# Altium schematic sheet LTE.SchDoc("LTE module")
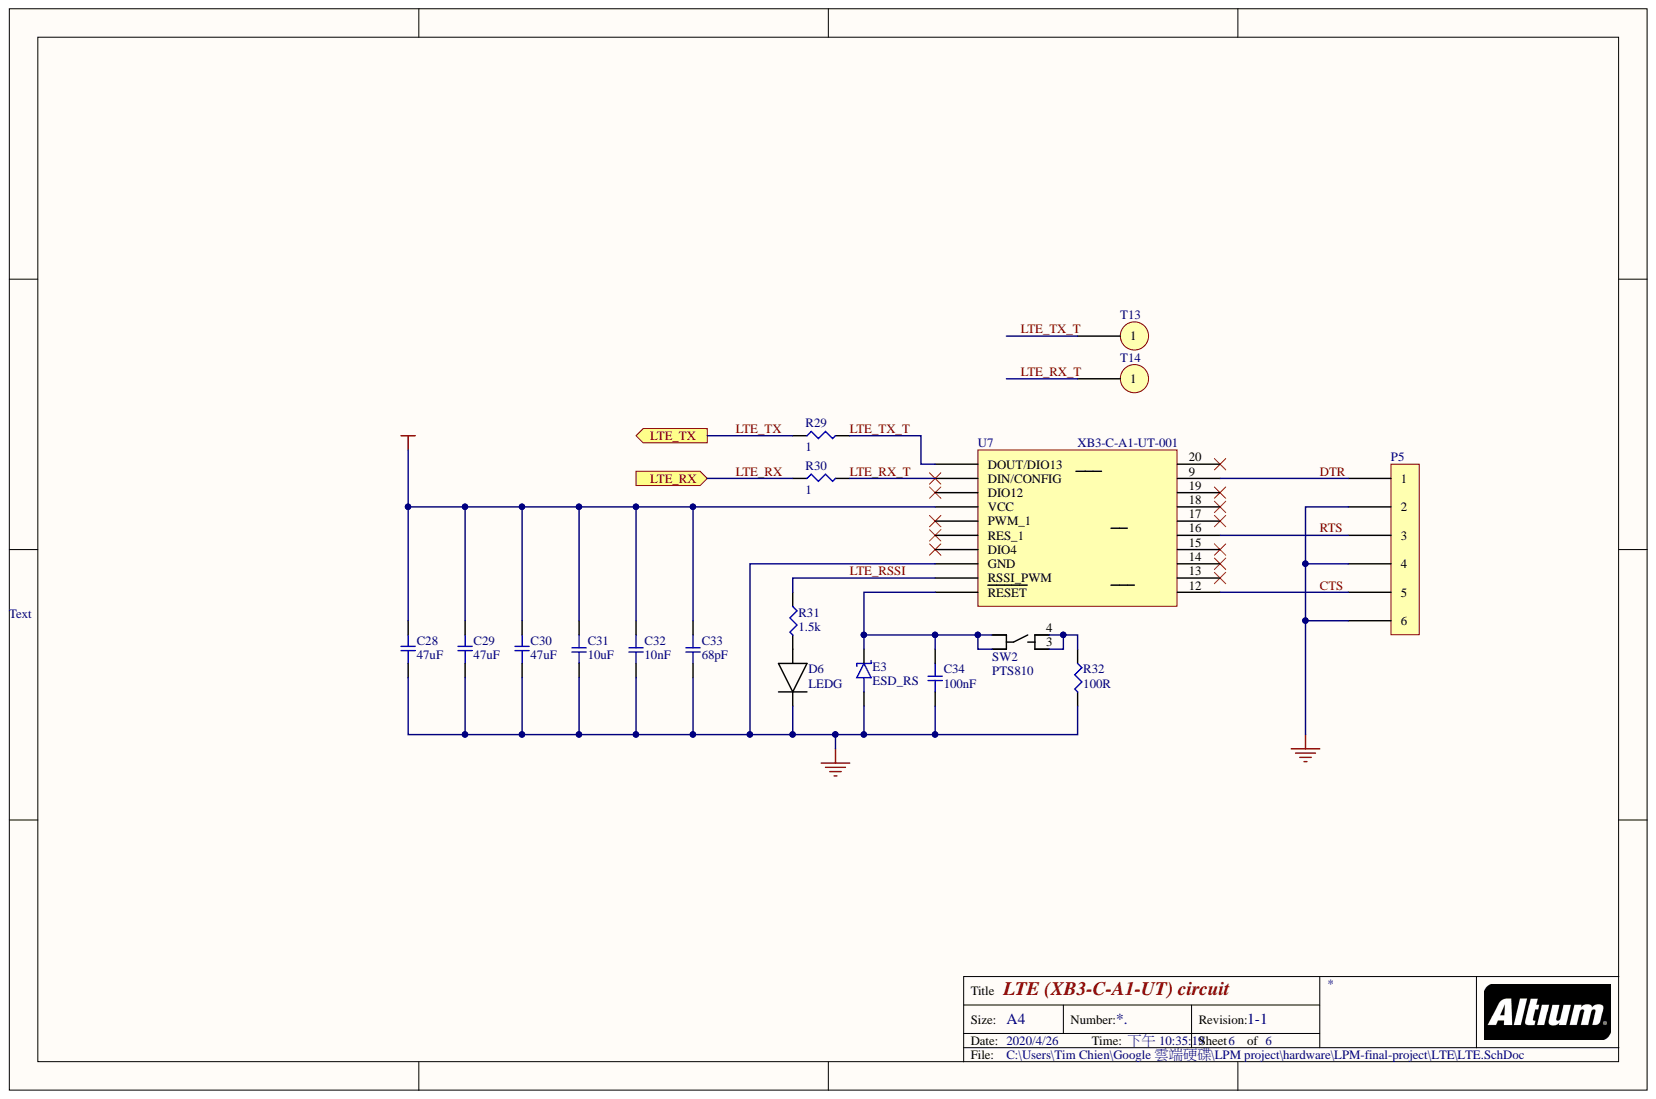
[diagram p0: LTE.SchDoc]

## Parts
C28  47uF  [0805-CAP]
C29  47uF  [0805-CAP]
C30  47uF  [0805-CAP]
C31  value 10uF  [0603-CAP]  (hidden comment: 0603C 10UF)
C32  value 10nF  [0603-CAP]  (hidden comment: 0603C 10NF)
C33  value 68pF  [0603-CAP]  (hidden comment: 0603C 68PF)
C34  value 100nF  [0603-CAP]  (hidden comment: 0603C 100NF)
D6  LEDG  [0603-LED]
E3  ESD_RS  [ESD_RS]
P5  6pins_connector  [6_PINS_2.54]  (value not drawn)
R29  value 1  [0603-RES]  (hidden comment: 0603R 1R)
R30  value 1  [0603-RES]  (hidden comment: 0603R 1R)
R31  value 1.5k  [0603-RES]  (hidden comment: 0603R 1K5R)
R32  value 100R  [0603-RES]  (hidden comment: 0603R 100R)
SW2  PTS810  [*SW_PTS810_SJM_250_SMTR_LFS]
T13  (no value)  [1_PIN_test]
T14  (no value)  [1_PIN_test]
U7  XB3-C-A1-UT-001  [XB24CAPIT-001]

## Ports
LTE_RX, LTE_TX

## Nets
3.3V: C28.2 C29.2 C30.2 C31.1 C32.1 C33.1 U7.1(VCC)
GND: C28.1 C29.1 C30.1 C31.2 C32.2 C33.2 C34.2 D6.2 E3.2 P5.2 P5.4 P5.6 R32.2 U7.10(GND)
CTS: P5.5 U7.12
DTR: P5.1 U7.9
LTE_RSSI: R31.1 U7.6(RSSI_PWM)
LTE_RX: R30.1 ; port LTE_RX
LTE_RX_T: R30.2 T14.1 U7.3(DIN/CONFIG)
LTE_TX: R29.1 ; port LTE_TX
LTE_TX_T: R29.2 T13.1 U7.2(DOUT/DIO13)
RTS: P5.3 U7.16
NetC34_1: C34.1 E3.1 SW2.1 SW2.2 U7.5(RESET)
NetD6_1: D6.1 R31.2
NetR32_1: R32.1 SW2.3 SW2.4

Not connected: U7 4(DIO12) 7(PWM_1) 8(RES_1) 11(DIO4) 13 14 15 17 18 19 20

## Library data of the parts
C28-C30 = 0805C 47UF: Value: 47uF; Supplier Part Number 1: 490-9949-1-ND; Supplier 1: Digi-Key; Library Name: passive components.SchLib; Component Kind: Standard
D6 = 0603 LEDG: Value: LEDG; Supplier Part Number 1: 160-1183-6-ND; Supplier 1: Digi-Key; Library Name: passive components.SchLib; Component Kind: Standard
E3 = ESD_RESET: Supplier Part Number 1: F7701CT-ND; Supplier 1: Digi-Key; Library Name: passive components.SchLib; Component Kind: Standard
SW2 = PTS810_SJM_250_SMTR_LFS: Tactile Switch SPST-NO Top Actuated Surface Mount; MP: PTS810 SJM 250 SMTR LFS; MF: C&K; SnapEDA_Link: https://www.snapeda.com/parts/PTS810%20SJM%20250%20SMTR%20LFS/C%26K/view-part/522770/?ref=snap; Price: 0.3; Package: None; Library Name: PTS810_SJM_250_SMTR_LFS.IntLib; Digikey part number: CKN10502CT-ND; Digikey link: https://www.digikey.com/product-detail/en/c-k/PTS810-SJM-250-SMTR-LFS/CKN10502CT-ND/4176673; Component Kind: Standard; Availability: Unavailable
T13 T14 = 1pin_header: Component Kind: Standard
U7 = XB3-C-A1-UT-001: MF: Digi; Price: 99; Package: 20-SMD Module; MP: XB3-C-A1-UT-001; Digikey part number: 602-2211-ND; Digikey link: https://www.digikey.com/product-detail/en/digi/XB3-C-A1-UT-001/602-2211-ND/8109033; Datasheet link: https://www.digi.com/pdf/ds_xbee3-cellular-cat1.pdf; Component Kind: Standard; Library Name: XBee_LTE.SchLib
C31 = 0603C 10UF: Supplier Part Number 1: 490-10474-1-ND; Supplier 1: Digi-Key; Library Name: passive components.SchLib; Component Kind: Standard
C32 = 0603C 10NF: Supplier Part Number 1: 490-8028-1-ND; Supplier 1: Digi-Key; Library Name: passive components.SchLib; Component Kind: Standard
C33 = 0603C 68PF: Supplier Part Number 1: 311-4039-1-ND; Supplier 1: Digi-Key; Library Name: passive components.SchLib; Component Kind: Standard
C34 = 0603C 100NF: Supplier Part Number 1: 490-8020-1-ND; Supplier 1: Digi-Key; Library Name: passive components.SchLib; Component Kind: Standard
P5 = 6pins_connector: Library Name: 2.54_Connectors.SchLib; Component Kind: Standard
R29 R30 = 0603R 1R: Supplier Part Number 1: 311-1.00HRCT-ND; Supplier 1: Digi-Key; Package: 0603; Library Name: passive components.SchLib; Component Kind: Standard
R31 = 0603R 1K5R: Supplier Part Number 1: 311-1.50KHRCT-ND; Supplier 1: Digi-Key; Package: 0603; Library Name: passive components.SchLib; Component Kind: Standard
R32 = 0603R 100R: Supplier Part Number 1: 311-100HRCT-ND; Supplier 1: Digi-Key; Package: 0603; Library Name: passive components.SchLib; Component Kind: Standard
